# Altium schematic sheet 08_ETH1.SchDoc
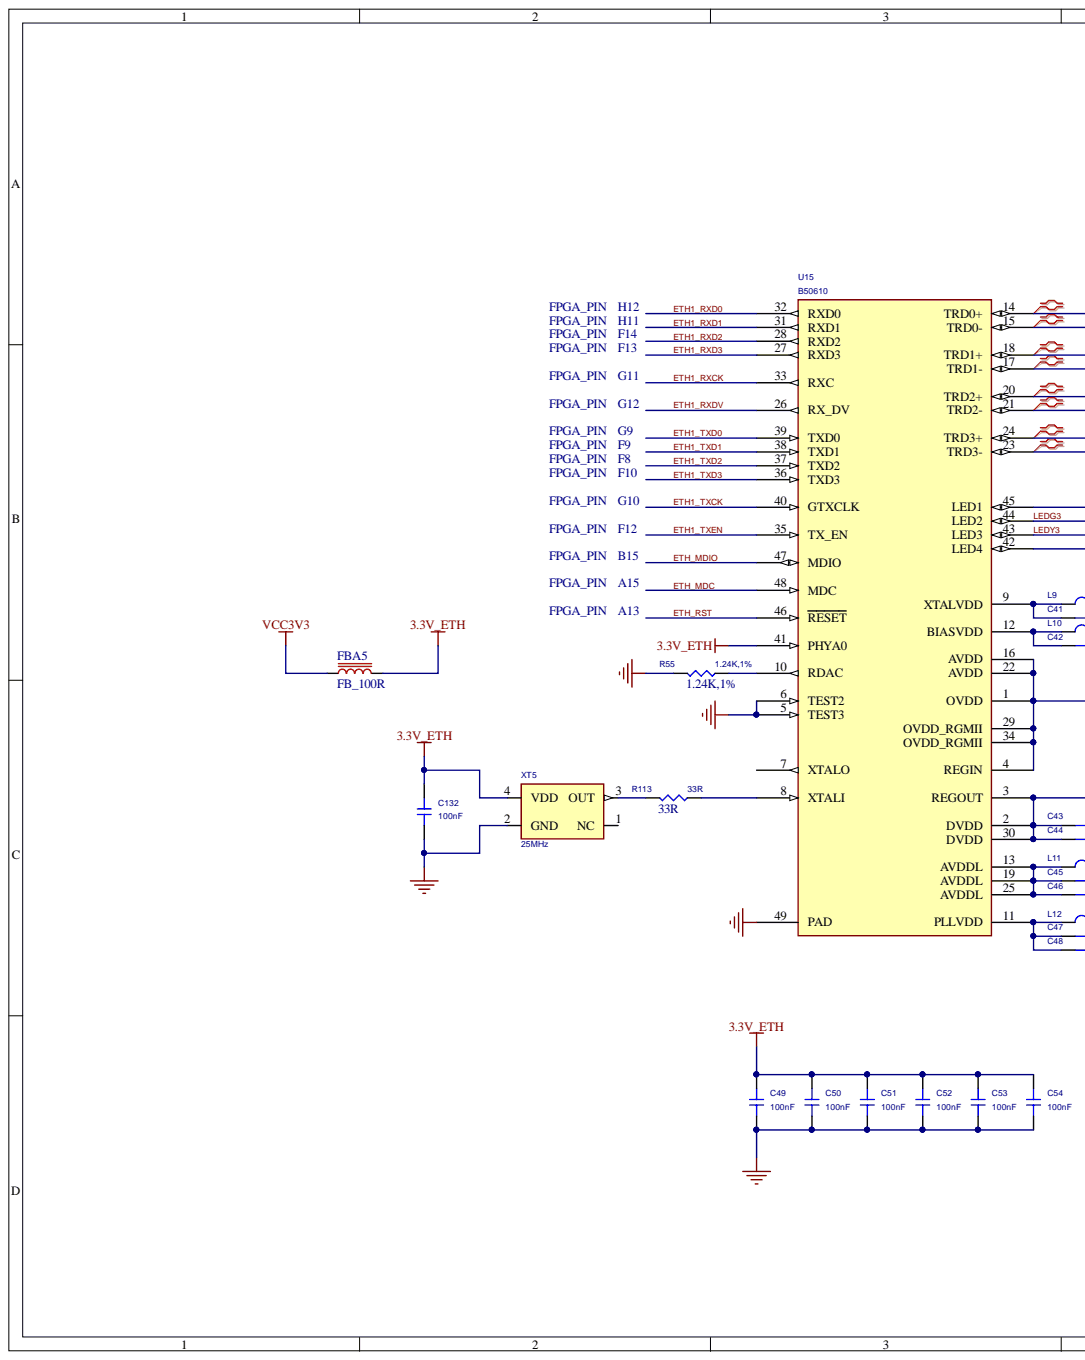
[diagram p0: 08_ETH1.SchDoc, left]
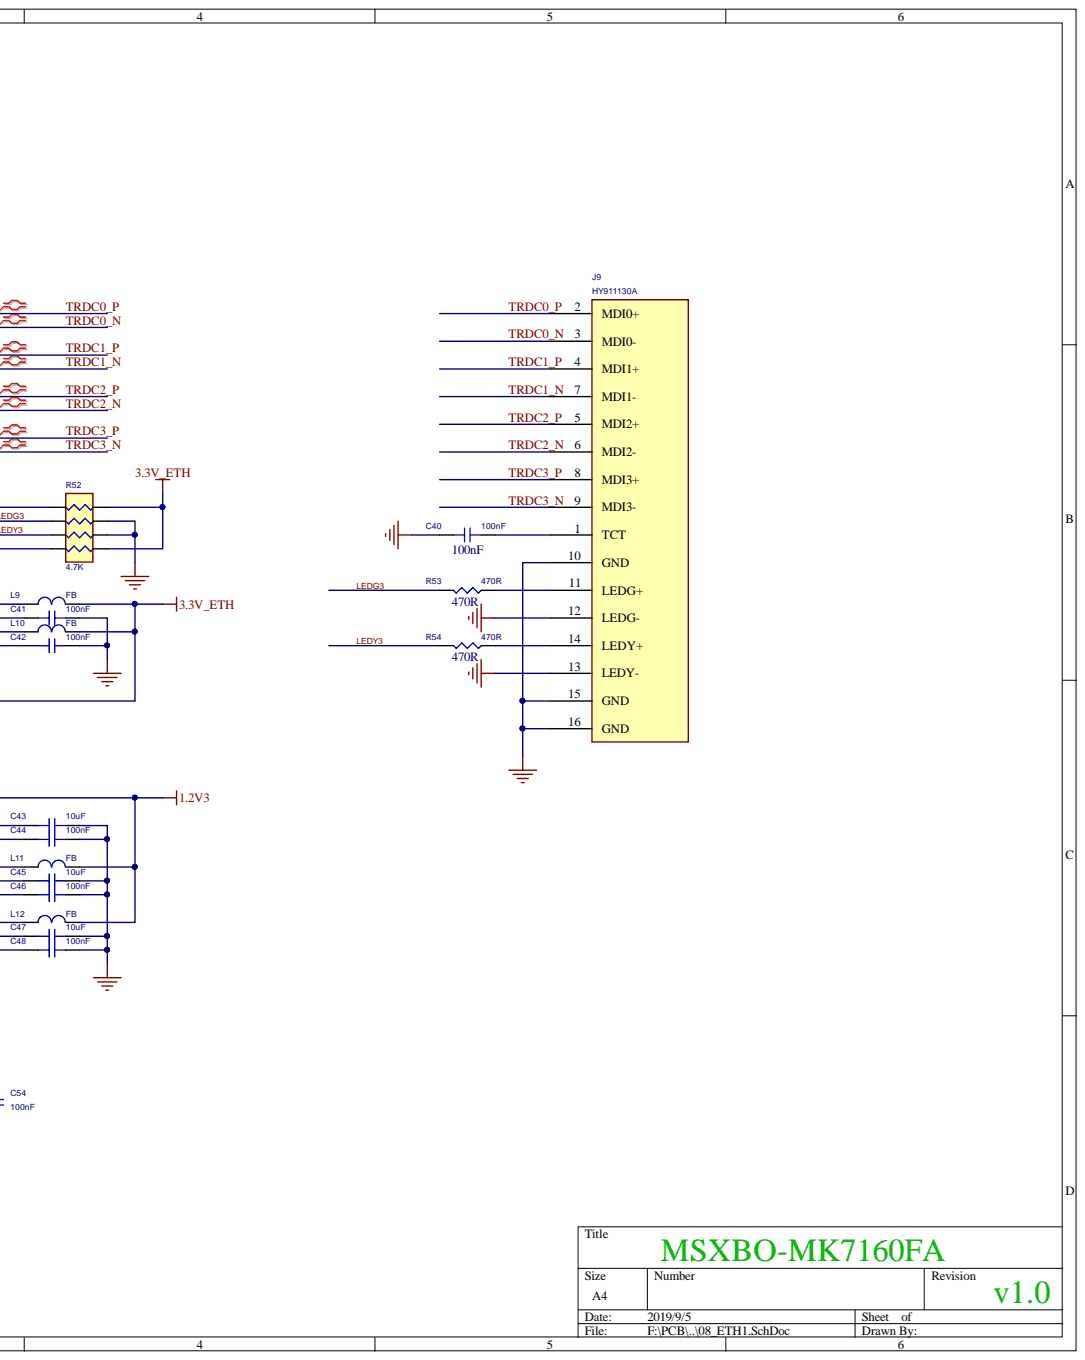
[diagram p1: 08_ETH1.SchDoc, right]

## Parts
C40  100nF  [CAP0402]
C41  100nF  [CAP0402]
C42  100nF  [CAP0402]
C43  10uF  [C0603]
C44  100nF  [CAP0402]
C45  10uF  [C0603]
C46  100nF  [CAP0402]
C47  10uF  [C0603]
C48  100nF  [CAP0402]
C49  100nF  [CAP0402]
C50  100nF  [CAP0402]
C51  100nF  [CAP0402]
C52  100nF  [CAP0402]
C53  100nF  [CAP0402]
C54  100nF  [CAP0402]
C132  100nF  [CAP0402]
FBA5  FB_100R  [CAP0603]
J9  HY911130A  [HY911130A]
L9  FB  [L0603]
L10  FB  [L0603]
L11  FB  [L0603]
L12  FB  [L0603]
R52  4.7K  [RA4_0603]
R53  470R  [R0603]
R54  470R  [R0603]
R55  1.24K,1%  [R0603]
R113  33R  [R0603]
U15  B50610  [QFN48_50P7X7X1_E4]
XT5  25MHz  [CRY3225_4]

## Nets
1.2V3: C43.1 C44.1 L11.2 L12.2 U15.2(DVDD) U15.3(REGOUT) U15.30(DVDD)
3.3V_ETH: C49.2 C50.2 C51.2 C52.2 C53.2 C54.2 C132.2 FBA5.2 L9.2 L10.2 R52.5 R52.8 U15.1(OVDD) U15.4(REGIN) U15.16(AVDD) U15.22(AVDD) U15.29(OVDD_RGMII) U15.34(OVDD_RGMII) U15.41(PHYA0) XT5.4(VDD)
GND: C40.1 C41.2 C42.2 C43.2 C44.2 C45.2 C46.2 C47.2 C48.2 C49.1 C50.1 C51.1 C52.1 C53.1 C54.1 C132.1 J9.10(GND) J9.12(LEDG-) J9.13(LEDY-) J9.15(GND) J9.16(GND) R52.6 R52.7 R55.1 U15.5(TEST3) U15.6(TEST2) U15.49(PAD) XT5.2(GND)
VCC3V3: FBA5.1
ETH1_RXCK: U15.33(RXC)
ETH1_RXD0: U15.32(RXD0)
ETH1_RXD1: U15.31(RXD1)
ETH1_RXD2: U15.28(RXD2)
ETH1_RXD3: U15.27(RXD3)
ETH1_RXDV: U15.26(RX_DV)
ETH1_TXCK: U15.40(GTXCLK)
ETH1_TXD0: U15.39(TXD0)
ETH1_TXD1: U15.38(TXD1)
ETH1_TXD2: U15.37(TXD2)
ETH1_TXD3: U15.36(TXD3)
ETH1_TXEN: U15.35(TX_EN)
ETH_MDC: U15.48(MDC)
ETH_MDIO: U15.47(MDIO)
ETH_RST: U15.46(RESET)
LEDG3: R52.2 R53.1 U15.44(LED2)
LEDY3: R52.3 R54.1 U15.43(LED3)
TRDC0_N: J9.3(MDI0-) U15.15(TRD0-)
TRDC0_P: J9.2(MDI0+) U15.14(TRD0+)
TRDC1_N: J9.7(MDI1-) U15.17(TRD1-)
TRDC1_P: J9.4(MDI1+) U15.18(TRD1+)
TRDC2_N: J9.6(MDI2-) U15.21(TRD2-)
TRDC2_P: J9.5(MDI2+) U15.20(TRD2+)
TRDC3_N: J9.9(MDI3-) U15.23(TRD3-)
TRDC3_P: J9.8(MDI3+) U15.24(TRD3+)
NetC40_2: C40.2 J9.1(TCT)
NetC41_1: C41.1 L9.1 U15.9(XTALVDD)
NetC42_1: C42.1 L10.1 U15.12(BIASVDD)
NetC45_1: C45.1 C46.1 L11.1 U15.13(AVDDL) U15.19(AVDDL) U15.25(AVDDL)
NetC47_1: C47.1 C48.1 L12.1 U15.11(PLLVDD)
NetJ9_11: J9.11(LEDG+) R53.2
NetJ9_14: J9.14(LEDY+) R54.2
NetR52_1: R52.1 U15.45(LED1)
NetR52_4: R52.4 U15.42(LED4)
NetR55_2: R55.2 U15.10(RDAC)
NetR113_1: R113.1 XT5.3(OUT)
NetR113_2: R113.2 U15.8(XTALI)
FPGA_PIN:

Not connected: U15 7(XTALO); XT5 1(NC)

## Library data of the parts
C40-C54 C132 = CAP1: CURRENT: CIMAX; DIST: FLAT; KNEE: CBMAX; MAX_TEMP: CTMAX; PSpiceTemplate: C^@REFDES %1 %2 ?TOLERANCE|C^@REFDES| @VALUE ?IC/IC=@IC/ TC=@TC1,@TC2 ?TOLERANCE|\n.model C^@REFDES CAP C=1 DEV=@TOLERANCE% TC1=@TC1 TC2=@TC2 VC1=@VC1 VC2=@VC2|; SLOPE: CSMAX; TC1: 0; VOLTAGE: CMAX; Component Kind: Standard; Simulation: CAP1; TC2: 0; VC1: 0; VC2: 0
  C40-C42 C44 C46 C48-C54 C132: Value: 100nF
  C43 C45 C47: Value: 10uF
FBA5 = Ferrite Bead: Generic Ferrite Bead; Value: 600; Component Kind: Standard; Library Name: MK7X(FUN)20190418.IntLib
L9-L12 = INDUCTOR1: CURRENT: LMAX; DIELECTRIC: DSMAX; DIST: FLAT; IL1: 0; PSpiceTemplate: L^@REFDES %1 %2 ?TOLERANCE|L^@REFDES| @VALUE ?IC/IC=@IC/ ?TOLERANCE|\n.model l^@REFDES IND L=1 DEV=@TOLERANCE% TC1=@TC1 TC2=@TC2 IL1=@IL1 IL2=@IL2|; Component Kind: Standard; IL2: 0; Simulation: INDUCTOR1; TC1: 0; TC2: 0; Value: FB
R52 = RA-4: Component Kind: Standard
R53-R55 R113 = RES1: DIST: FLAT; MAX_TEMP: RTMAX; POWER: RMAX; PSpiceTemplate: R^@REFDES %1 %2 ?TOLERANCE|R^@REFDES| @VALUE TC=@TC1,@TC2 ?TOLERANCE|\n.model R^@REFDES RES R=1 DEV=@TOLERANCE% TC1=@TC1 TC2=@TC2|; SLOPE: RSMAX; TC1: 0; VOLTAGE: RVMAX; Component Kind: Standard; Simulation: RES1; TC2: 0
  R53 R54: Value: 470R
  R55: Value: 1.24K,1%
  R113: Value: 33R
XT5 = CRYSTAL3: Component Kind: Standard
J9 = HY911130A: Component Kind: Standard
U15 = B50610: Component Kind: Standard
